# Altium schematic sheet Disarmer.SchDoc
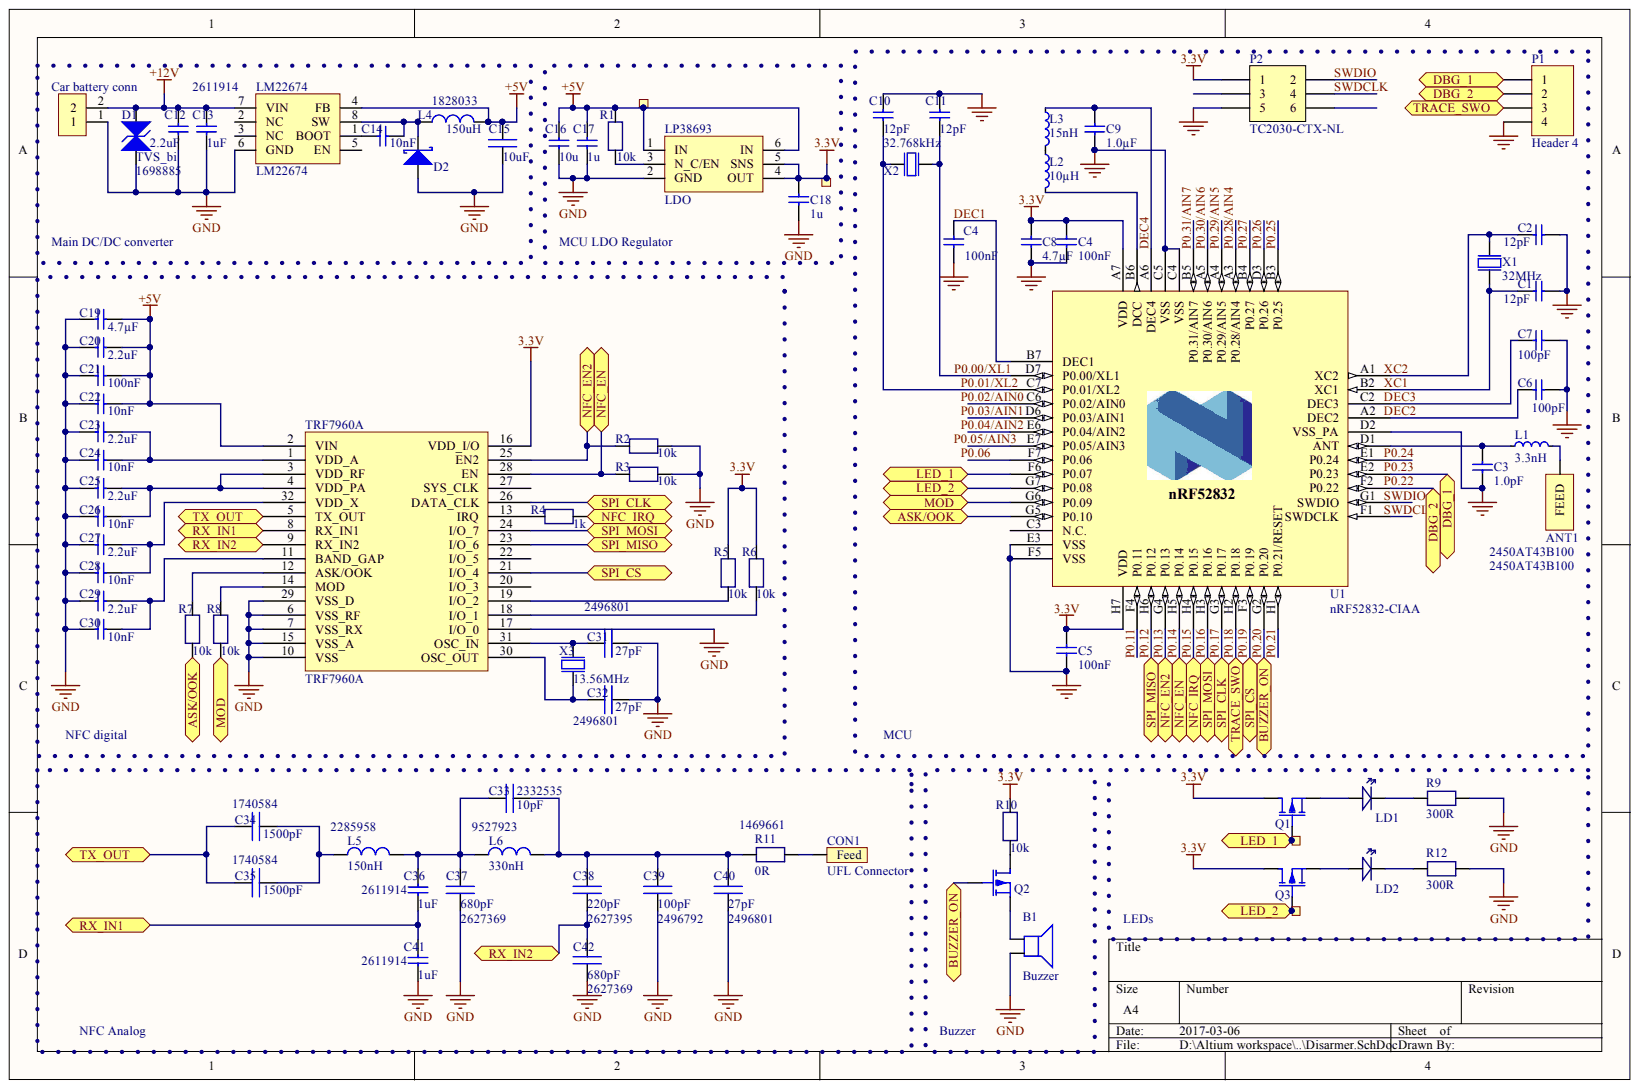
[diagram p0: Disarmer.SchDoc]

## Parts
1  Ø1.0mm,SMD  [TP_SMD_Ø1.0mm]  (value not drawn)  (no BOM)
2  Ø1.0mm,SMD  [TP_SMD_Ø1.0mm]  (value not drawn)  (no BOM)
3  Ø1.0mm,SMD  [TP_SMD_Ø1.0mm]  (value not drawn)  (no BOM)
4  Ø1.0mm,SMD  [TP_SMD_Ø1.0mm]  (value not drawn)  (no BOM)
ANT1  2450AT43B100  [2450AT43B100]
B1  Buzzer  [Buzzer_12mm]
C1  12pF  [CAPC0603X03L]
C2  12pF  [CAPC0603X03L]
C3  1.0pF  [CAPC0603X03L]
C4  100nF  [CAPC0603X03L]
C4  100nF  [CAPC0603X03L]
C5  100nF  [CAPC0603X03L]
C6  100pF  [CAPC0603X03L]
C7  100pF  [CAPC0603X03L]
C8  4.7µF  [CAPC1608X06L]
C9  1.0µF  [CAPC1005X04L]
C10  12pF  [CAPC0603X03L]
C11  12pF  [CAPC0603X03L]
C12  2.2uF  [CAPC1608X06L]
C13  1uF  [CAPC1608X06L]
C14  10nF  [CAPC0603X03L]
C15  10uF  [0805]
C16  10u  [CAPC1608X06L]
C17  1u  [CAPC1608X06L]
C18  1u  [CAPC1608X06L]
C19  4.7µF  [CAPC1608X06L]
C20  2.2uF  value 4.7µF  [CAPC1608X06L]
C21  100nF  [CAPC0603X03L]
C22  10nF  value 100nF  [CAPC0603X03L]
C23  2.2uF  [CAPC1608X06L]
C24  10nF  [CAPC0603X03L]
C25  2.2uF  [CAPC1608X06L]
C26  10nF  [CAPC0603X03L]
C27  2.2uF  [CAPC1608X06L]
C28  10nF  [CAPC0603X03L]
C29  2.2uF  [CAPC1608X06L]
C30  10nF  [CAPC0603X03L]
C31  27pF  Supplier Part Number 1: 2496801  [0402]
C32  27pF  Supplier Part Number 1: 2496801  [0402]
C33  10pF  Supplier Part Number 1: 2332535  [0402]
C34  1500pF  Supplier Part Number 1: 1740584  [0402]
C35  1500pF  Supplier Part Number 1: 1740584  [0402]
C36  1uF  Supplier Part Number 1: 2611914  [CAPC1608X06L]
C37  680pF  Supplier Part Number 1: 2627369  [0402]
C38  220pF  Supplier Part Number 1: 2627395  [0402]
C39  100pF  Supplier Part Number 1: 2496792  [0402]
C40  27pF  Supplier Part Number 1: 2496801  [0402]
C41  1uF  Supplier Part Number 1: 2611914  [CAPC1608X06L]
C42  680pF  Supplier Part Number 1: 2627369  [0402]
Car_battery_conn  (no value)  [ARK_3.5mm]
CON1  UFL Connector  [UFL SMD]
D1  TVS_bi  Supplier Part Number 1: 1698885  [DO-214-AB]
D2  Shottky  [SOD123F]  (value not drawn)
L1  3.3nH  [INDC0603X03L]
L2  10µH  [INDC1608X06L]
L3  15nH  [INDC1005X04L]
L4  150uH  Supplier Part Number 1: 1828033  [8x8]
L5  150nH  Supplier Part Number 1: 2285958  [0603]
L6  330nH  Supplier Part Number 1: 9527923  [0805]
LD1  0603_LED  [LED_0603]  (value not drawn)
LD2  0603_LED  [LED_0603]  (value not drawn)
LM22674  LM22674  [HSOP 8-pin]
LP38693  LDO  [WSON-6]
P1  Header 4  [HDR1X4]
P2  TC2030-CTX-NL  [TC2030-IDC-NLFP]  (no BOM)
Q1  RZE002P02TLTR-ND  [SOT-416]  (value not drawn)
Q2  RZE002P02TLTR-ND  [SOT-416]  (value not drawn)
Q3  RZE002P02TLTR-ND  [SOT-416]  (value not drawn)
R1  value 10k  [RES_0402]  (hidden comment: RES_0402_1k)
R2  value 10k  [RES_0402]  (hidden comment: RES_0402_1k)
R3  value 10k  [RES_0402]  (hidden comment: RES_0402_1k)
R4  value 1k  [RES_0402]  (hidden comment: RES_0402_1k)
R5  value 10k  [RES_0402]  (hidden comment: RES_0402_1k)
R6  value 10k  [RES_0402]  (hidden comment: RES_0402_1k)
R7  value 10k  [RES_0402]  (hidden comment: RES_0402_1k)
R8  value 10k  [RES_0402]  (hidden comment: RES_0402_1k)
R9  value 300R  [RES_0402]  (hidden comment: RES_0402_1k)
R10  value 10k  [RES_0402]  (hidden comment: RES_0402_1k)
R11  value 0R  Supplier Part Number 2: 1469661  [RES_0402]  (hidden comment: RES_0402_1k)
R12  value 300R  [RES_0402]  (hidden comment: RES_0402_1k)
TRF7960A  TRF7960A  [RHB32]
U1  nRF52832-CIAA  [BGA50C40P7X8_295X322X50]
X1  32MHz  [BT-XTAL_2016]
X2  32.768kHz  [XTAL_2012]
X3  13.56MHz  [BT-XTAL_2016]

## Ports
ASK/OOK, BUZZER_ON, DBG_1, DBG_2, LED_1, LED_2, MOD, NFC_EN, NFC_EN2, NFC_IRQ, RX_IN1, RX_IN2, SPI_CLK, SPI_CS, SPI_MISO, SPI_MOSI, TRACE_SWO, TX_OUT

## Nets
3.3V: 2.1 C4.1 C5.1 C8.1 C18.1 LP38693.4(OUT) LP38693.5(SNS) P2.1 Q1.3 Q3.3 R5.2 R6.2 R10.1 TRF7960A.16(VDD_I/O) U1.A7(VDD) U1.H7(VDD)
+5V: 1.1 C15.2 C16.1 C17.1 C19.2 C20.1 C21.2 C22.2 L4.2 LM22674.4(FB) LP38693.1(IN) LP38693.6(IN) R1.2 TRF7960A.2(VIN)
+12V: C12.1 C13.1 Car_battery_conn.2 D1.2 LM22674.7(VIN)
GND: B1.2 C1.1 C2.1 C3.1 C4.2 C5.2 C6.1 C7.2 C8.2 C9.1 C10.2 C11.2 C12.2 C13.2 C15.1 C16.2 C17.2 C18.2 C19.1 C20.2 C21.1 C22.1 C23.2 C24.1 C25.2 C26.1 C27.2 C28.1 C29.2 C30.1 C31.1 C32.1 C37.1 C39.1 C40.2 C41.2 C42.1 Car_battery_conn.1 CON1.2 D1.1 D2.1 LM22674.6(GND) LP38693.2(GND) P1.4 P2.5 R2.1 R3.1 R9.1 R12.1 TRF7960A.6(VSS_RF) TRF7960A.7(VSS_RX) TRF7960A.10(VSS) TRF7960A.15(VSS_A) TRF7960A.17(I/O_0) TRF7960A.29(VSS_D) U1.C4(VSS) U1.C5(VSS) U1.D2(VSS_PA) U1.E3(VSS) U1.F5(VSS) X1.2 X1.4 X3.2 X3.4
ASK/OOK: R7.1 U1.G5(P0.10) ; port ASK/OOK
DBG_1: P1.1 U1.E2(P0.23) ; port DBG_1
DBG_2: P1.2 U1.F2(P0.22) ; port DBG_2
DEC1: C4.1 U1.B7(DEC1)
DEC4: C9.2 L3.1 U1.A6(DEC4)
LED_1: 3.1 Q1.1 U1.F6(P0.07) ; port LED_1
LED_2: 4.1 Q3.1 U1.G7(P0.08) ; port LED_2
MOD: R8.1 U1.G6(P0.09) ; port MOD
P0.00/XL1: C11.1 U1.D7(P0.00/XL1) X2.2
P0.01/XL2: C10.1 U1.C7(P0.01/XL2) X2.1
P0.11: U1.F4(P0.11)
P0.12: TRF7960A.23(I/O_6) U1.H6(P0.12) ; port SPI_MISO
P0.13: R2.2 TRF7960A.25(EN2) U1.G4(P0.13) ; port NFC_EN2
P0.14: R3.2 TRF7960A.28(EN) U1.H5(P0.14) ; port NFC_EN
P0.15: R4.2 U1.H4(P0.15) ; port NFC_IRQ
P0.16: TRF7960A.24(I/O_7) U1.H3(P0.16) ; port SPI_MOSI
P0.17: TRF7960A.26(DATA_CLK) U1.G3(P0.17) ; port SPI_CLK
P0.18: P1.3 U1.H2(P0.18) ; port TRACE_SWO
P0.19: TRF7960A.21(I/O_4) U1.F3(P0.19) ; port SPI_CS
P0.20: Q2.1 U1.G2(P0.20) ; port BUZZER_ON
P0.21: U1.H1(P0.21/RESET)
P0.25: U1.B3(P0.25)
P0.26: U1.D3(P0.26)
P0.27: U1.B4(P0.27)
P0.28/AIN4: U1.A3(P0.28/AIN4)
P0.29/AIN5: U1.A4(P0.29/AIN5)
P0.30/AIN6: U1.A5(P0.30/AIN6)
P0.31/AIN7: U1.B5(P0.31/AIN7)
RX_IN1: C36.2 C41.1 TRF7960A.8(RX_IN1) ; port RX_IN1
RX_IN2: C38.1 C42.2 TRF7960A.9(RX_IN2) ; port RX_IN2
SWDCLK: P2.4
SWDIO: P2.2
TX_OUT: C34.1 C35.1 TRF7960A.5(TX_OUT) ; port TX_OUT
NetANT1_1: ANT1.1 L1.2
NetB1_1: B1.1 Q2.2
NetC1_2: C1.2 U1.B2(XC1) X1.1
NetC2_2: C2.2 U1.A1(XC2) X1.3
NetC3_2: C3.2 L1.1 U1.D1(ANT)
NetC6_2: C6.2 U1.A2(DEC2)
NetC7_1: C7.1 U1.C2(DEC3)
NetC14_1: C14.1 D2.2 L4.1 LM22674.8(SW)
NetC14_2: C14.2 LM22674.1(BOOT)
NetC23_1: C23.1 C24.2 TRF7960A.1(VDD_A)
NetC25_1: C25.1 C26.2 TRF7960A.3(VDD_RF) TRF7960A.4(VDD_PA)
NetC27_1: C27.1 C28.2 TRF7960A.32(VDD_X)
NetC29_1: C29.1 C30.2 TRF7960A.11(BAND_GAP)
NetC31_2: C31.2 TRF7960A.31(OSC_IN) X3.3
NetC32_2: C32.2 TRF7960A.30(OSC_OUT) X3.1
NetC33_1: C33.1 C38.2 C39.2 C40.1 L6.2 R11.2
NetC33_2: C33.2 C36.1 C37.2 L5.2 L6.1
NetC34_2: C34.2 C35.2 L5.1
NetCON1_1: CON1.1 R11.1
NetL2_1: L2.1 L3.2
NetL2_2: L2.2 U1.B6(DCC)
NetLD1_1: LD1.1 Q1.2
NetLD1_2: LD1.2 R9.2
NetLD2_1: LD2.1 Q3.2
NetLD2_2: LD2.2 R12.2
NetLP38693_3: LP38693.3(N_C/EN) R1.1
NetP2_3: P2.3
NetP2_6: P2.6
NetQ2_3: Q2.3 R10.2
NetR4_1: R4.1 TRF7960A.13(IRQ)
NetR5_1: R5.1 TRF7960A.19(I/O_2)
NetR6_1: R6.1 TRF7960A.18(I/O_1)
NetR7_2: R7.2 TRF7960A.12(ASK/OOK)
NetR8_2: R8.2 TRF7960A.14(MOD)
NetTRF7960A_20: TRF7960A.20(I/O_3)
NetTRF7960A_22: TRF7960A.22(I/O_5)
NetTRF7960A_27: TRF7960A.27(SYS_CLK)
NetU1_C3: U1.C3(N.C.)
NetU1_C6: U1.C6(P0.02/AIN0)
NetU1_D6: U1.D6(P0.03/AIN1)
NetU1_E1: U1.E1(P0.24)
NetU1_E6: U1.E6(P0.04/AIN2)
NetU1_E7: U1.E7(P0.05/AIN3)
NetU1_F1: U1.F1(SWDCLK)
NetU1_F7: U1.F7(P0.06)
NetU1_G1: U1.G1(SWDIO)
DEC2:
DEC3:
P0.02/AIN0:
P0.03/AIN1:
P0.04/AIN2:
P0.05/AIN3:
P0.06:
P0.22:
P0.23:
P0.24:
XC1:
XC2:

Not connected: LM22674 2(NC) 3(NC) 5(EN)

## Library data of the parts
1-4 = TESTPAD: 1.0mm circular SMD testpad; Manufacturer: Nordic Semiconductor; Artikkelnr Noca: N.A.; LatestRevisionDate: 2013-06-11; Library Name: pca20006.IntLib; Part Number: TP_SMD_Ø1.0MM; Pin Count: 1; Published: 2013-06-11; Value: Ø1.0mm,SMD; Publisher: Nordic Semiconductor
C1 C2 C10 C11 = C0201-12p: Capacitor, NP0, ±2%; Artikkelnr Noca: N.A.; Database Table Name: Capacitors$; Note: 50V; Artikkelnr Simpro: N.A.; Component Kind: Standard; Footprint Doc: 0201; LatestRevisionDate: 2014-11-13; Library Name: Nordic Passive DB.DbLib; Part Number: C0201-12p; Pin Count: 2; Published: 2014-11-13; Value: 12pF; Publisher: Nordic Semiconductor
C3 = C0201-1.0p: Capacitor, NP0, ±5%; Artikkelnr Noca: N.A.; Database Table Name: Capacitors$; Note: Chip Cap 1%; Artikkelnr Simpro: N.A.; Component Kind: Standard; Footprint Doc: 0201; LatestRevisionDate: 2014-11-13; Library Name: Nordic Passive DB.DbLib; Part Number: C0201-1.0p; Pin Count: 2; Published: 2014-11-13; Value: 1.0pF; Publisher: Nordic Semiconductor
C4 C4 C5 C21 C22 = C0201-100n: Capacitor, X7R, ±10%; Artikkelnr Noca: N.A.; Database Table Name: Capacitors$; Note: 16V; Artikkelnr Simpro: N.A.; Component Kind: Standard; Footprint Doc: 0201; LatestRevisionDate: 2014-11-13; Library Name: Nordic Passive DB.DbLib; Part Number: C0201-100n; Pin Count: 2; Published: 2014-11-13; Publisher: Nordic Semiconductor
  C4 C4 C5 C21: Value: 100nF
C6 C7 = C0201-100p: Capacitor, NP0, ±5%; Artikkelnr Noca: N.A.; Database Table Name: Capacitors$; Note: Chip Cap 1%; Artikkelnr Simpro: N.A.; Component Kind: Standard; Footprint Doc: 0201; LatestRevisionDate: 2014-11-13; Library Name: Nordic Passive DB.DbLib; Part Number: C0201-100p; Pin Count: 2; Published: 2014-11-13; Value: 100pF; Publisher: Nordic Semiconductor
C8 C19 C20 = C0603-4µ7: Capacitor, X5R, ±10%; Artikkelnr Noca: 20069060; Artikkelnr Simpro: N.A.; Database Table Name: Capacitors$; Note: 6.3V; Component Kind: Standard; Footprint Doc: 0603; LatestRevisionDate: 2009-11-23; Library Name: Nordic Passive DB.DbLib; Part Number: C0603-4µ7; Pin Count: 2; Published: 2009-05-08; Publisher: Nordic Semiconductor
  C8 C19: Value: 4.7µF
C9 = C0402-1µ0: Capacitor, X5R, ±5%; Artikkelnr Noca: 20039235; Artikkelnr Simpro: N.A.; Database Table Name: Capacitors$; Note: 6.3V; Component Kind: Standard; Footprint Doc: 0402; LatestRevisionDate: 2009-11-23; Library Name: Nordic Passive DB.DbLib; Part Number: C0402-1µ0; Pin Count: 2; Published: 2009-05-08; Value: 1.0µF; Publisher: Nordic Semiconductor
C12 C13 C16-C18 C23 C25 C27 C29 C36 C41 = C0603-4µ7: Capacitor, X5R, ±10%; Artikkelnr Noca: 20069060; Artikkelnr Simpro: N.A.; Database Table Name: Capacitors$; Note: 6.3V; Value: 4.7µF; Component Kind: Standard; Footprint Doc: 0603; LatestRevisionDate: 2009-11-23; Library Name: Nordic Passive DB.DbLib; Part Number: C0603-4µ7; Pin Count: 2; Published: 2009-05-08; Publisher: Nordic Semiconductor
  C13: Supplier 1: Farnell; Supplier Part Number 1: 2611914
  C16-C18: Supplier 1: Farnell; Supplier Part Number 1: 1327684
  C36 C41: Supplier 1: Farnell
C14 C24 C26 C28 C30 = C0201-100n: Capacitor, X7R, ±10%; Artikkelnr Noca: N.A.; Database Table Name: Capacitors$; Note: 16V; Value: 100nF; Artikkelnr Simpro: N.A.; Component Kind: Standard; Footprint Doc: 0201; LatestRevisionDate: 2014-11-13; Library Name: Nordic Passive DB.DbLib; Part Number: C0201-100n; Pin Count: 2; Published: 2014-11-13; Publisher: Nordic Semiconductor
C15 C31-C35 C37-C40 C42 = Capacitor_MLCC: ?; Component Kind: Standard; Library Name: Capacitors.SchLib
  C31-C35 C37-C40 C42: Supplier 1: Farnell
Car_battery_conn = Component_1: Component Kind: Standard; Library Name: Terminal_block.SchLib
D1 = TVS_bidirectional: Component Kind: Standard; Description: TVS_bi; Library Name: Diodes.SchLib; Supplier 1: Farnell
D2 = Shottky_diode: Component Kind: Standard; Library Name: Diodes.SchLib
L1 = L0201-3n3: High frequency chip inductor ±5%; Artikkelnr Noca: N.A.; Database Table Name: Inductors$; Artikkelnr Simpro: N.A.; Component Kind: Standard; Footprint Doc: 0201; LatestRevisionDate: 2013-04-10; Library Name: Nordic Passive DB.DbLib; Note: High frequency chip inductor ±5%; Part Number: L0201-3n3; Pin Count: 2; Published: 2013-04-10; Value: 3.3nH; Publisher: Nordic Semiconductor
L2 = L0603-10µ: Chip inductor, IDC,min = 50 mA, ±20%; 2nd source part number: 178-6105; Manufacturer: Taiyo Yuden; Manufacturer Part Number: LBMF1608T100K; Artikkelnr Noca: 33029314; Artikkelnr Simpro: N.A.; Database Table Name: Inductors$; Note: LBMF1608T100K 10µH 80mA 0603; 2nd source Supplier: Farnell; Component Kind: Standard; Datasheet: http://www.yuden.co.jp/ut/product/pdf/elb2_e.pdf; Footprint Doc: 0603; LatestRevisionDate: 2012-09-19; Library Name: Nordic Passive DB.DbLib; Part Number: L0603-10µ; Pin Count: 2; Published: 2010-02-24; Supplier: Digi-Key; Supplier part number: 587-1714-1-ND; Value: 10µH; Publisher: Nordic Semiconductor
L3 = L0402-15n: High frequency chip inductor ±10%; 2nd source part number: 658-1328; Manufacturer: Taiyo Yuden; Manufacturer Part Number: HK100515NJ-T; Artikkelnr Noca: 33029323; Artikkelnr Simpro: N.A.; Database Table Name: Inductors$; 2nd source Supplier: Farnell; Component Kind: Standard; Datasheet: http://www.yuden.co.jp/productdata/catalog/en/mlci04_e.pdf; Footprint Doc: 0402; LatestRevisionDate: 2012-09-19; Library Name: Nordic Passive DB.DbLib; Note: High frequency chip inductor ±10%; Part Number: L0402-15n; Pin Count: 2; Published: 2010-07-12; Supplier: Digi-Key; Supplier part number: 587-1521-1-ND; Value: 15nH; Publisher: Nordic Semiconductor
L4-L6 = Inductor: Component Kind: Standard; Library Name: Inductors.SchLib; Supplier 1: Farnell
LD1 LD2 = LED_0603_Blue: Component Kind: Standard; Supplier 1: Digi-Key; Supplier 2: TME; Supplier 3: TME; Supplier 4: TME; Supplier 5: TME; Supplier Part Number 1: 160-1647-2-ND; Supplier Part Number 2: LL-S192PBC-B4-1B; Supplier Part Number 3: LL-S194PBC-B4-1B; Supplier Part Number 4: OF-SMD1608B; Supplier Part Number 5: OSB50603C1D; Library Name: ONEMETER.SchLib
LP38693 = LP38693 WSON Package: Component Kind: Standard; Library Name: LP38693_LDOSchLib.SchLib
P1 = Header 4: Header, 4-Pin; Component Kind: Standard; LatestRevisionDate: 17-Jul-2002; LatestRevisionNote: Re-released for DXP Platform.; Library Name: Miscellaneous Connectors.IntLib; Signal Integrity: Connector; Publisher: Altium Limited
P2 = TC2030-CTX-NL: CBL PLUG-OF-NAILS 6-PIN; Manufacturer: Tag-Connect LLC; Artikkelnr Noca: N.A.; Database Table Name: Connectors$; Datasheet: http://www.tag-connect.com/Materials/TC2030-IDC-NL.pdf; LatestRevisionDate: 2013-08-08; Library Name: Nordic Passive DB.DbLib; Manufacturer Part Number: TC2030-CTX-NL; Part Number: TC2030-CTX-NL; Pin Count: 6; Published: 2013-08-08; Supplier: Tag-Connect; Supplier part number: TC2030-CTX-NL; Value: TC2030-CTX-NL; Publisher: Nordic Semiconductor
U1 = nRF52832-CIAA: Multi-protocol Bluetooth Low Energy, ANT and 2.4GHz proprietary system-on-chip; Manufacturer: Nordic Semiconductor; Artikkelnr Noca: N.A.; Database Table Name: nRF Devices$; Artikkelnr Simpro: N.A.; Component Kind: Standard; Footprint Doc: WLCSP_C50; LatestRevisionDate: 2016-03-11; Library Name: Nordic Active DB.DbLib; Manufacturer Part Number: nRF52832-CIAA; Part Number: nRF52832-CIAA; Part number IPS: N.A.; Pin Count: 54; Published: 2016-03-11; Supplier: Nordic Semiconductor; Value: nRF52832-CIAA; Publisher: Nordic Semiconductor
X1 X3 = XTAL_SMD2016_32MHz_8pF_Epson: XTAL SMD 2016, 32MHz, Cl=8pF, Total Tol: ±40ppm; Manufacturer: Epson Toyocom; Manufacturer Part Number: Q22FA12800025; Artikkelnr Noca: N.A.; Database Table Name: Crystal_Osc$; Note: XTAL SMD 32.000MHz; Artikkelnr Simpro: N.A.; Component Kind: Standard; Datasheet: http://www.epsondevice.com/docs/qd/en/DownloadServlet?id=ID000449; Footprint Doc: XTAL_2016; LatestRevisionDate: 2014-11-27; Library Name: Nordic Discrete DB.DbLib; Part Number: XTAL_SMD2016_32MHz_8pF_Epson; Pin Count: 4; Published: 2012-03-09; Supplier: Farnell; Supplier part number: 171-2806; Value: 32MHz; Publisher: Nordic Semiconductor
X2 = XTAL_SMD2012_32.768kHz_9pF_Seiko: XTAL SMD 2012, 32.768kHz, Cl=9pF, Total Tol: ±50ppm; Manufacturer: Seiko; Manufacturer Part Number: SC20S-9.0PF20PPM; Artikkelnr Noca: N.A.; Database Table Name: Crystal_Osc$; Note: XTAL SMD 32.768kHz, 2012; Artikkelnr Simpro: N.A.; Component Kind: Standard; Datasheet: http://www.sii.co.jp/en/quartz/files/2013/03/file_PRODUCT_MASTER_50811_GRAPHIC03.pdf; Footprint Doc: XTAL_2012; LatestRevisionDate: 2015-10-15; Library Name: Nordic Discrete DB.DbLib; Part Number: XTAL_SMD2012_32.768kHz_9pF_Seiko; Pin Count: 2; Published: 2015-10-15; Value: 32.768kHz; Publisher: Nordic Semiconductor
ANT1 = 2450AT43B100: Component Kind: Standard; Supplier 1: Mouser; Supplier 2: Farnell; Supplier 3: Farnell; Supplier 4: Digi-Key; Supplier 5: Digi-Key; Supplier 6: Digi-Key; Supplier Part Number 1: 609-2450AT43B100E; Supplier Part Number 2: 2148524; Supplier Part Number 3: 2148524RL; Supplier Part Number 4: 712-1010-2-ND; Supplier Part Number 5: 712-1010-1-ND; Supplier Part Number 6: 712-1010-6-ND; Value: 2450AT43B100; Library Name: ONEMETER.SchLib
B1 = Buzzer: Component Kind: Standard; Library Name: Buzzers.SchLib
CON1 = UFL Connector: Component Kind: Standard; Supplier 1: Digi-Key; Supplier 2: Digi-Key; Supplier 3: Digi-Key; Supplier 4: Digi-Key; Supplier 5: Digi-Key; Supplier 6: Digi-Key; Supplier 7: Farnell; Supplier 8: Farnell; Supplier Part Number 1: H9161DKR-ND; Supplier Part Number 2: H11891CT-ND; Supplier Part Number 3: H11891DKR-ND; Supplier Part Number 4: H9161CT-ND; Supplier Part Number 5: H9161TR-ND; Supplier Part Number 6: H11891TR-ND; Supplier Part Number 7: 1688077; Supplier Part Number 8: 1688077RL; Library Name: ONEMETER.SchLib
LM22674 = LM22674: Component Kind: Standard; Library Name: LM22674.SchLib
Q1-Q3 = RZE002P02TLTR-ND: Component Kind: Standard; Supplier 1: Digi-Key; Supplier 2: Digi-Key; Supplier 3: Digi-Key; Supplier Part Number 1: RZE002P02TLTR-ND; Supplier Part Number 2: RZE002P02TLCT-ND; Supplier Part Number 3: RZE002P02TLDKR-ND; Value: RZE002P02TL; Library Name: ONEMETER.SchLib
R1-R12 = RES_0402_1k: Component Kind: Standard; Supplier 2: Farnell; Library Name: ONEMETER.SchLib
  R1-R10 R12: Supplier 1: Digi-Key; Supplier 3: Mouser; Supplier Part Number 1: 311-1.0KJRTR-ND; Supplier Part Number 2: 1358043; Supplier Part Number 3: 603-RC0402FR-071KL
  R11: Supplier 1: Mouser; Supplier Part Number 1: 603-RC0402FR-071KL
TRF7960A = TRF7960A: Component Kind: Standard; Library Name: NFC.SchLib
